# Altium schematic sheet transmitter.SchDoc(transmitter)
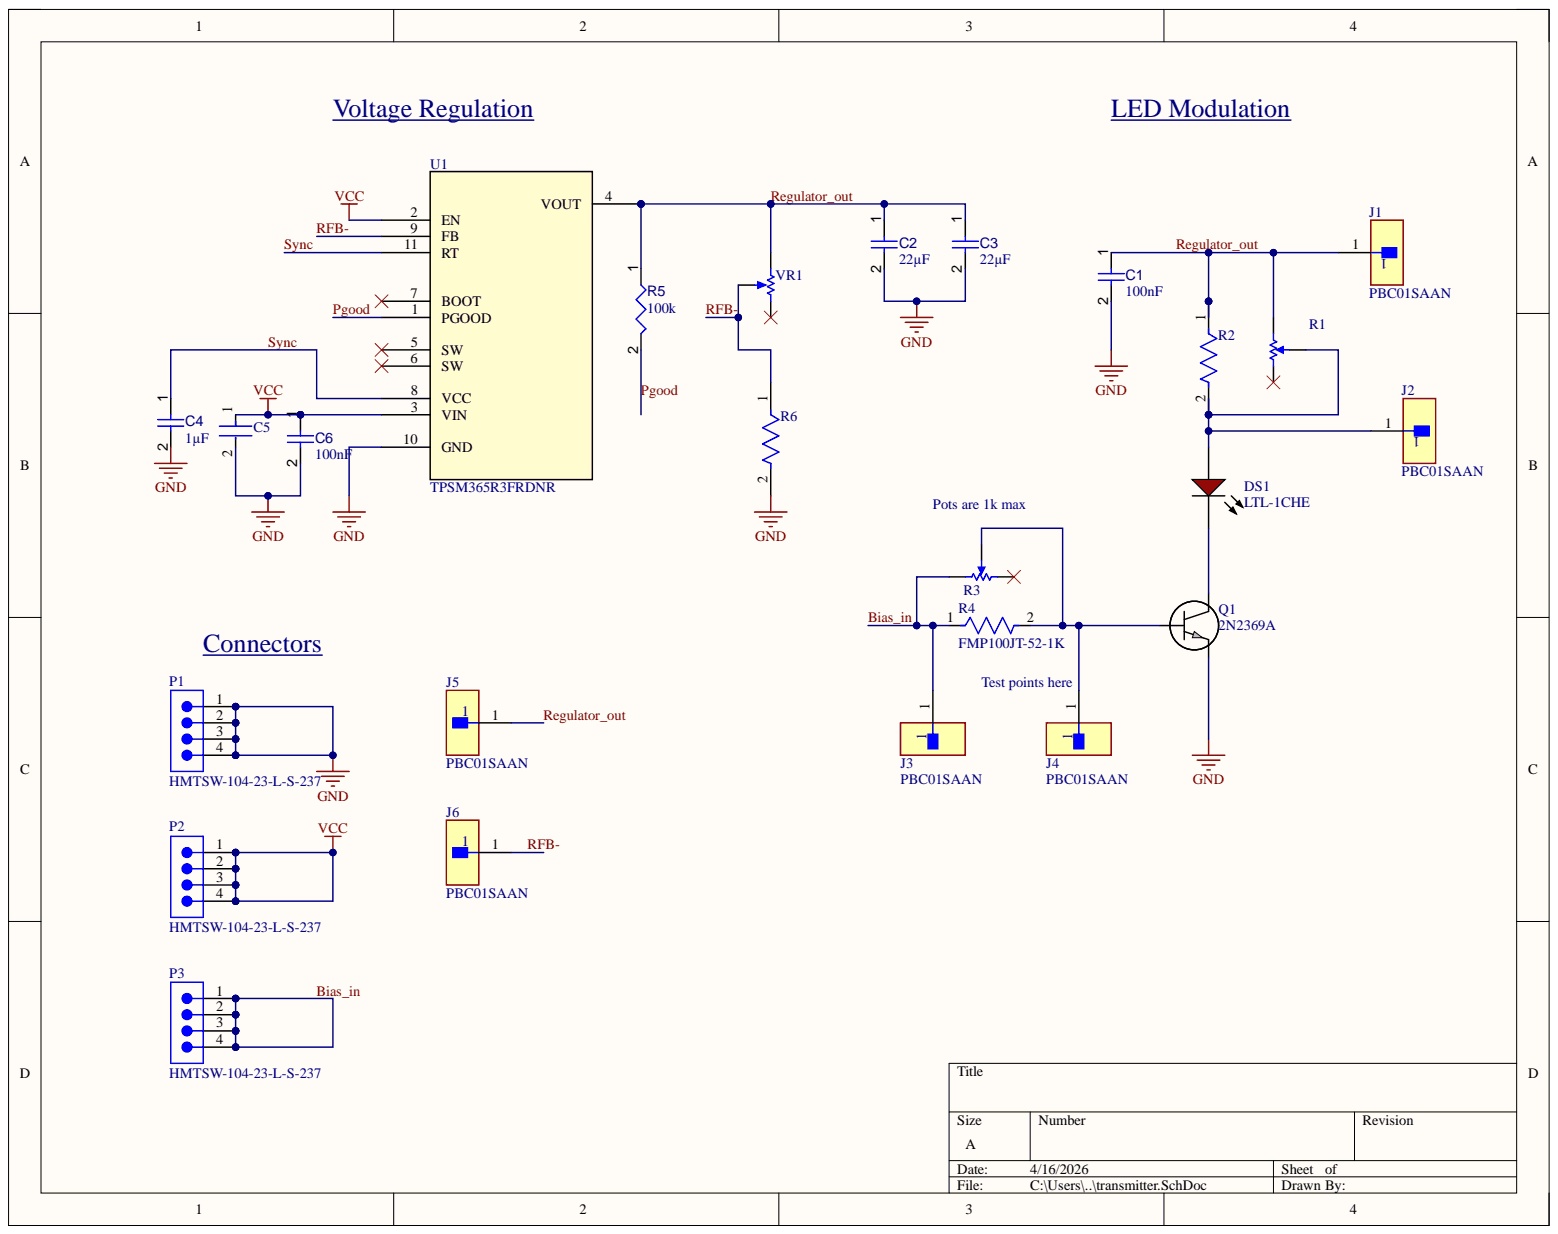
[diagram p0: transmitter.SchDoc]

## Parts
C1  value 100nF  [CAPC0402(1005)60_L]  (hidden comment: Capacitor 100nF +/-20% 50V 0402)
C2  value 22µF  [CAPC0805(2012)145_L]  (hidden comment: Capacitor 22µF +/-40% 16V 0805)
C3  value 22µF  [CAPC0805(2012)145_L]  (hidden comment: Capacitor 22µF +/-40% 16V 0805)
C4  value 1µF  [CAPC0603(1608)100_L]  (hidden comment: Capacitor 1µF +/-0.1% 25V 0603)
C5  GRM21BD72A225ME01K  [FP-GRM21B-0_2-e0_2_0_7-IPC_B]  (value not drawn)
C6  value 100nF  [CAPC2013X95X50LL10T25]  (hidden comment: Capacitor 100 nF +/-10% 50 V 0805)
DS1  LTL-1CHE  [INDPR60-254-520X380X650]
J1  PBC01SAAN  [FP-PBC01SAAN-MFG]
J2  PBC01SAAN  [FP-PBC01SAAN-MFG]
J3  PBC01SAAN  [FP-PBC01SAAN-MFG]
J4  PBC01SAAN  [FP-PBC01SAAN-MFG]
J5  PBC01SAAN  [FP-PBC01SAAN-MFG]
J6  PBC01SAAN  [FP-PBC01SAAN-MFG]
P1  HMTSW-104-23-L-S-237  [SMTC-HMTSW-104-23-X-S-237]
P2  HMTSW-104-23-L-S-237  [SMTC-HMTSW-104-23-X-S-237]
P3  HMTSW-104-23-L-S-237  [SMTC-HMTSW-104-23-X-S-237]
Q1  2N2369A  [TO-18_STM]
R1  3296W-1-102LF  [BOUR-3296W-1-3]  (value not drawn)
R2  MBA02040C2200FC100  [FP-MBA0204-MFG]  (value not drawn)
R3  3296W-1-102LF  [BOUR-3296W-1-3]  (value not drawn)
R4  FMP100JT-52-1K  [FP-FMP100-MFG]
R5  value 100k  [RESC1608X55X25ML10T15]  (hidden comment: Resistor 100k +/-1% 0603 100 mW)
R6  AC2512FK-0710KL  [FP-AC2512-MFG]  (value not drawn)
U1  TPSM365R3FRDNR  [QFN-FCMOD_365R6FRDN_TEX]
VR1  3362P-1-104LF  [BOUR-3362P]  (value not drawn)

## Nets
GND: C1.2 C2.2 C3.2 C4.2 C5.2 C6.2 P1.1 P1.2 P1.3 P1.4 Q1.3 R6.2 U1.10(GND)
VCC: C5.1 C6.1 P2.1 P2.2 P2.3 P2.4 U1.2(EN) U1.3(VIN)
Bias_in: J3.1 P3.1 P3.2 P3.3 P3.4 R3.2 R4.1
Pgood: R5.2 U1.1(PGOOD)
Regulator_out: C1.1 C2.1 C3.1 J1.1 J5.1 R1.2 R2.1 R5.1 U1.4(VOUT) VR1.1
RFB-: J6.1 R6.1 U1.9(FB) VR1.2
Sync: C4.1 U1.8(VCC) U1.11(RT)
NetDS1_1: DS1.1 J2.1 R1.3 R2.2
NetDS1_2: DS1.2 Q1.1
NetJ4_1: J4.1 Q1.2 R3.3 R4.2

Not connected: R1 1; R3 1; U1 5(SW) 6(SW) 7(BOOT); VR1 3

## Library data of the parts
C1 = CMP-001-00067-3: Chip Capacitor, 100nF +/-20%, 50V, 0402, Thickness 0.6 mm; Tolerance: 10%; Case/Package: 0402; Component Kind: Standard; Max Operating Temperature: 85°C; Min Operating Temperature: -55°C; Mounting Technology: SMT; Pins: 2; Voltage Rating: 50V; Library Name: XCOM_capstone
C2 C3 = CMP-001-00081-4: Chip Capacitor, 22µF +/-40%, 16V, 0805, Thickness 1.45 mm; Tolerance: 5%; Case/Package: 0805; Component Kind: Standard; Max Operating Temperature: 85°C; Min Operating Temperature: -55°C; Mounting Technology: SMT; Pins: 2; Voltage Rating: 16V; Library Name: XCOM_capstone
C4 = CMP-001-00055-4: Chip Capacitor, 1µF +/-0.1%, 25V, 0603, Thickness 1 mm; Tolerance: 20%; Case/Package: 0603; Component Kind: Standard; Max Operating Temperature: 85°C; Min Operating Temperature: -55°C; Mounting Technology: SMT; Pins: 2; Voltage Rating: 25V; Library Name: XCOM_capstone
C5 = CMP-06035-063309-1: CAP CER 2.2UF 100V X7T 0805; Component Kind: Standard; Library Name: Altium Content Vault
C6 = CMP-001-00018-6: Chip Capacitor, 100 nF, +/- 10%, 50 V, 0805 (2012 Metric); Capacitor Type: Ceramic; Voltage Rating: 50V; Case/Package: 0805; Component Kind: Standard; Max Operating Temperature: 125°C; Min Operating Temperature: -55°C; Mounting Technology: SM; Pins: 2; RoHS Compliant: True; Tolerance: 10%; Library Name: XCOM_capstone
DS1 = CMP-007-00018-2: LED Uni-Color Red, 100 mW, 30 mA, -55 to 100 degC, 2-Pin THD, RoHS, Bulk; Manufacturer: Lite-On; Forward Current: 20mA; Forward Voltage: 2V; Lens Style: Dome; Luminous Intensity: 12.6mcd; Viewing Angle: 60degrees; Case/Package: Radial; Colour: Red; Component Kind: Standard; Datasheet URL: https://datasheet.ciiva.com/15117/getdatasheetpartid-187746-15117985.pdf; Datasheet Version: Dec-03; Illumination Colour: Red; Manufacturer URL: http://www.us.liteon.com/; Max Operating Temperature: 100°C; Min Operating Temperature: -55°C; Mounting Technology: TH; Nominal Vf: 2V; Package Description: 2-Pin Through Hole Device, Dia 3.8 mm, Pitch 2.54 mm; Package Quantity: 1000; Package Reference: LTL-1CHE; Packaging: Bulk; Pins: 2; RoHS Compliant: True; Library Name: XCOM_capstone
J1-J6 = CMP-25680-000032-1: CONN HEADER VERT 1POS; Connector Type: Male Pin; Gender: Male; Number of Contacts: 1; Pitch: 2.54mm; Color: Black; Component Kind: Standard; Contact Material: Bronze; Contact Mating Length: 0.23inch; Contact Plating: Gold; Height: 2.54mm; Housing Color: Black; Housing Material: Plastic; Lead Free: Lead Free; Length: 2.54mm; Library Name: Altium Content Vault; Mount: Through Hole; Number of Positions: 1; Number of Rows: 1; Package Quantity: 1; Packaging: Bulk; Termination: Solder; Width: 2.54mm
P1-P3 = CMP-1024-00020-1: Modified .025" SQ Post Header, Through-hole, Vertical, -55 to 125 degC, 2.54 mm Pitch, 4-Pin, Male, RoHS; Manufacturer: Samtec; Pitch: 2.54 mm; Component Kind: Standard; ComponentLink1Description: Manufacturer URL; ComponentLink1URL: http://www.samtec.com; ComponentLink2Description: Datasheet; ComponentLink2URL: http://www.samtec.com/documents/webfiles/pdf/HMTSW.PDF; ComponentLink3Description: Package Specification; ComponentLink3URL: http://www.samtec.com/documents/webfiles/cpdf/HMTSW-XXX-XX-X-X-XXXX-XX-XX-MKT1.pdf; DatasheetVersion: F-214; Library Name: Altium Content Vault; Mounting Technology: Through-hole; Orientation: Vertical; PackageDescription: Assembly .025 [.64] SQ. Post Hi-Temp Terminal Strip; PackageReference: HMTSW-104-23-X-S-237; PackageVersion: AL, 3/1987; PartNumber: HMTSW-104-23-L-S-237; RoHS: True; Temperature Range: -55 to 125 degC
Q1 = CMP-010-000000-1: Description; Manufacturer_Name: STMicroelectronics; Component Kind: Standard; Design Item ID: 2N2369A; Manufacturer_Part_Number: 2N2369A; Source: 2N2369A.SchLib; Copyright: Copyright (C) 2025 Ultra Librarian. All rights reserved.; Library Name: XCOM_capstone
R1 R3 = CMP-009-00037-2: Square Trimpot(R) Trimming Potentiometer, 1000 Ohm, -55 to 125 degC, 3-Pin THD, RoHS, Tube; Manufacturer: Bourns; Power: 500mW; Resistance: 1k; Tolerance: 10%; Voltage Rating: 300V; Case/Package: 3296W-1-3; Component Kind: Standard; Composition: Potentiometer; Datasheet URL: http://www.bourns.com/docs/Product-Datasheets/3296.pdf; Datasheet Version: May-16; Manufacturer URL: http://www.bourns.com/; Max Operating Temperature: 150°C; Min Operating Temperature: -55°C; Mounting Technology: TH; Package Description: 3-Pin Through Hole Device, Body 9.53 x 4.83 mm, Pitch 2.54 mm; Package Quantity: 50; Package Reference: 3296W-1-3; Packaging: Rail/Tube; RoHS Compliant: True; Library Name: XCOM_capstone
R2 = CMP-02408-000005-1: RES 220 OHM 0.4W 1% AXIAL; Manufacturer: Vishay BC Components; Automotive Grade: Grade 1; Power Rating: 0.25W; Resistance: 220Ω; Temperature Coefficient: 50ppm/°C; Value: 220Ω; Voltage Rating: 200V; Automotive: Yes; Category: Res; Component Kind: Standard; ComponentLink2Description: Datasheet; ComponentLink2URL: http://www.vishay.com/docs/28766/mbxsma.pdf; Composition: Metal Film; Device Class L1: Passive Components; Device Class L2: Resistors; Device Class L3: Through-Hole Resistors; Digikey Description: RES 220 OHM 0.4W 1% AXIAL; Height: 1.6mm; Ipc Land Pattern Name: RESAD500W65L360D160; Lead Free: Yes; Library Name: Altium Content Vault; Manufacturer Part Number: MBA02040C2200FC100; Mouser Description: Thin Film Resistors - Through Hole .4watt 220ohms 1% 1/8watt body size; Octopart: https://octopart.com/mba02040c2200fc100-vishay-43743094; Package: MBA0204; RoHS: TRUE; Series: MBA/SMA 0204; Temperature: -55°C to +125°C; Temperature Range High: +125°C; Temperature Range Low: -55°C; Tolerance: 1%
R4 = CMP-00621-25629781-1: Resistor, 1 kOhm, ± 5%, 1 W, Axial Leaded, Metal Film, High Power Flame Proof; Power Rating: 1W; Resistance: 1kΩ; Temperature Coefficient: 100ppm/°C; Case/Package: Conformal; Component Kind: Standard; Composition: Metal Film; Diameter: 2.4mm; Lead Free: Lead Free; Length: 6.3mm; Library Name: Altium Content Vault; Max Operating Temperature: 155°C; Military Standard: Not; Min Operating Temperature: -55°C; Mount: Through Hole; Radiation Hardening: No; RoHS: Compliant; Schedule B: 8533210090, 8533210090; Termination: Axial; Tolerance: 5%
R5 = CMP-009-00023-5: Chip Resistor, 100 KOhm, +/- 1%, 0.1 W, -55 to 155 degC, 0603 (1608 Metric); Power: 100mW; Voltage Rating: 50V; Case/Package: 0603; Component Kind: Standard; Max Operating Temperature: 155°C; Min Operating Temperature: -55°C; Mounting Technology: SM; Pins: 2; RoHS Compliant: True; Tolerance: 1%; Library Name: XCOM_capstone
R6 = CMP-03412-001312-1: RES SMD 10K OHM 1% 1W 2512; Manufacturer: Yageo; Automotive Grade: Grade 0; Resistance: 10KΩ; Tolerance: 0.01; Value: 10KΩ; Voltage Rating: 200V; Automotive: Yes; Category: Res; Component Kind: Standard; ComponentLink2Description: Datasheet; ComponentLink2URL: http://www.yageo.com/documents/recent/PYu-AC_51_RoHS_L_6.pdf; Composition: Thick Film; Device Class L1: Passive Components; Device Class L2: Resistors; Device Class L3: Chip SMD Resistors; Digikey Description: RES SMD 10K OHM 1% 1W 2512; Footprint Url: http://www.yageo.com/exep/pages/download/literatures/PYu-R_Mount_10.pdf; Height: 0.65mm; Ipc Land Pattern Name: RESC635310X55; Lead Free: Yes; Library Name: Altium Content Vault; Manufacturer Part Number: AC2512FK-0710KL; Octopart: https://octopart.com/ac2512fk-0710kl-yageo-71369732; Package: AC2512; Power Rating: 1W; RoHS: TRUE; Series: AC; Temperature: -55°C to +155°C; Temperature Range High: +155°C; Temperature Range Low: -55°C
U1 = CMP-007-000000-1: 3-V to 65-V input, 1-V to 13-V output, 0.3-A synchronous buck converter power module 11-QFN-FCMOD -40 to 125; Manufacturer_Name: Texas Instruments; Component Kind: Standard; Design Item ID: TPSM365R3FRDNR; Manufacturer_Part_Number: TPSM365R3FRDNR; RefDes: RefDes; Source: TPSM365R3FRDNR.SchLib; Type: DC DC Converters; Copyright: Copyright (C) 2025 Ultra Librarian. All rights reserved.; Library Name: XCOM_capstone
VR1 = CMP-1747-00003-1: Square Trimpot(R) Trimming Potentiometer, 100 KOhm, 300 V, -55 to 125 degC, 3-Pin THD, RoHS, Tube; Case\Package: Axial; Power Rating: 250 mW; Resistance: 1 mohm; Tolerance: 1%; Voltage Rating: 250 V; Component Kind: Standard; ComponentLink1Description: Manufacturer URL; ComponentLink1URL: http://www.bourns.com/; ComponentLink2Description: Datasheet; ComponentLink2URL: http://www.bourns.com/docs/Product-Datasheets/3362.pdf; DatasheetVersion: May-16; Length: 0.248 inch; Library Name: Altium Content Vault; Max Operating Temperature: 155 degC; Min Operating Temperature: -55 degC; Mount: Through Hole; Package Quantity: 200; PackageDescription: 3-Pin Through Hole Device, Body 6.6 x 6.99 mm, Pitch 2.54 mm; PackageReference: 3326P; Packaging: Bulk; RoHSCompliant: true
